# Altium schematic sheet JTAG_to_HDMI.SchDoc(JTAG_to_HDMI)
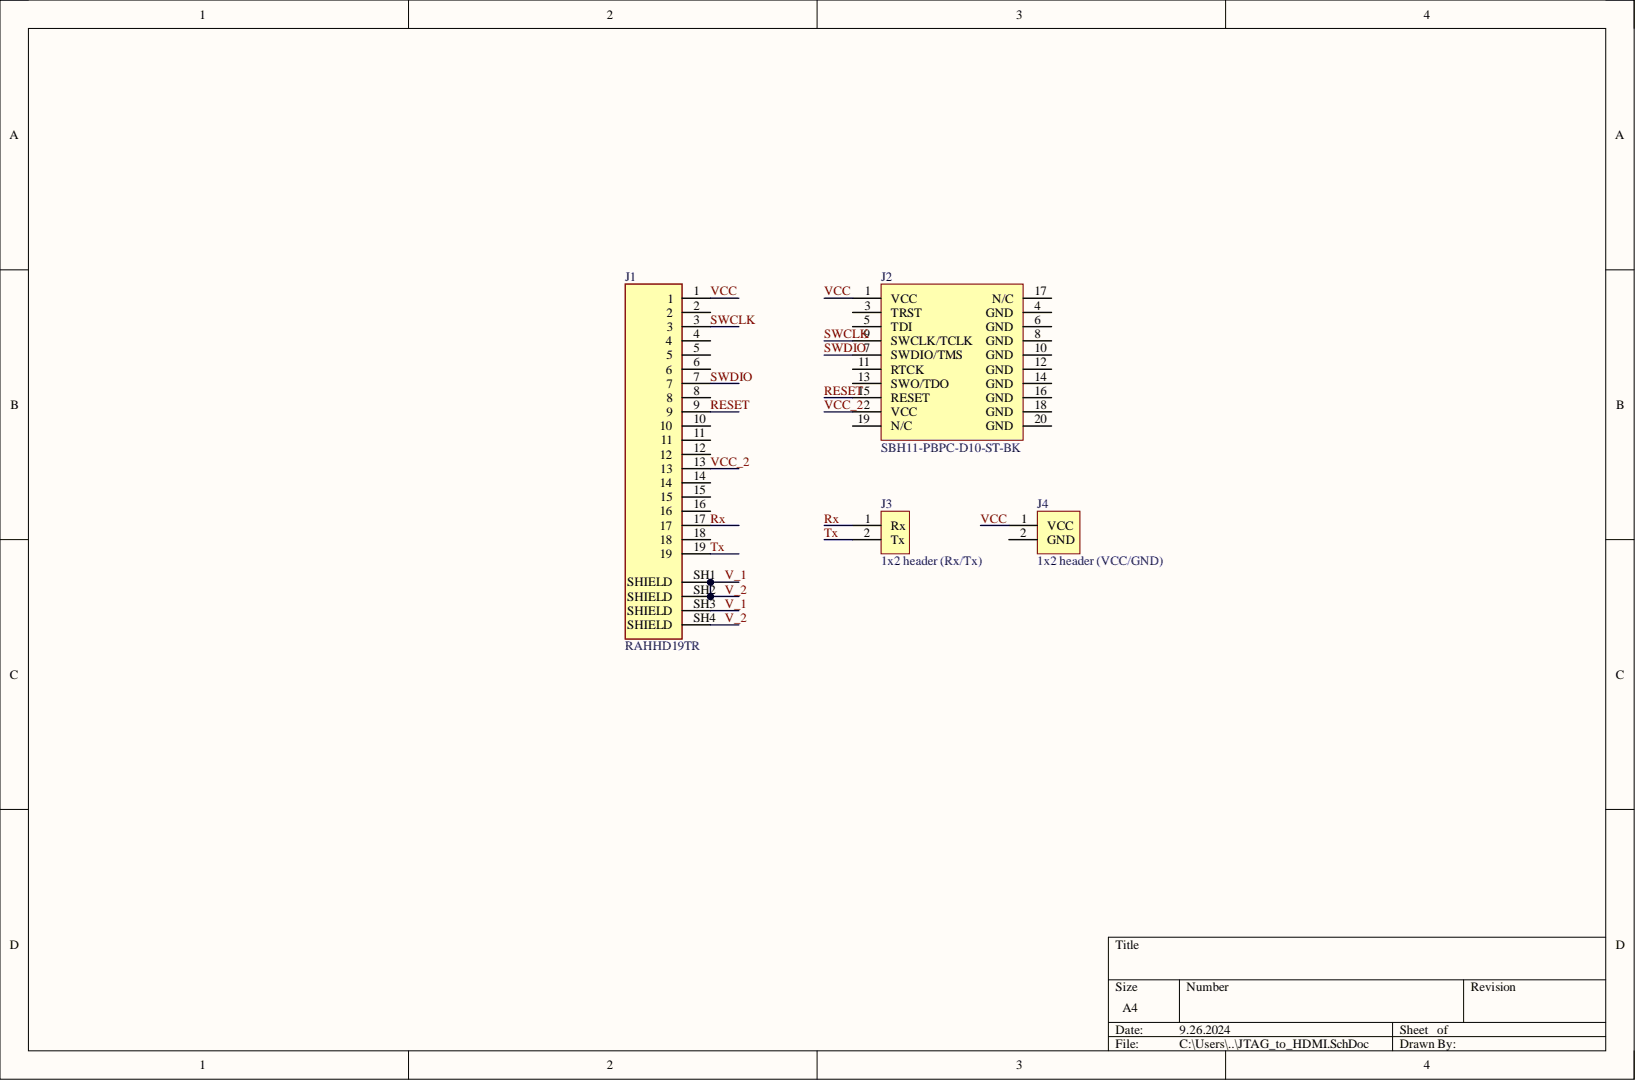
[diagram p0: JTAG_to_HDMI.SchDoc]

## Parts
J1  RAHHD19TR  [SWITCHCRAFT_RAHHD19TR]
J2  SBH11-PBPC-D10-ST-BK  [SBH11-PBPC-D10-ST-BK]
J3  1x2 header (Rx/Tx)  [DS1021-1*2SF1-1]
J4  1x2 header (VCC/GND)  [DS1021-1*2SF1-1]

## Nets
RESET: J1.9 J2.15(RESET)
Rx: J1.17 J3.1
SWCLK: J1.3 J2.9(SWCLK/TCLK)
SWDIO: J1.7 J2.7(SWDIO/TMS)
Tx: J1.19 J3.2
V_1: J1.SH1(SHIELD) J1.SH2(SHIELD) J1.SH3(SHIELD) J1.SH4(SHIELD)
VCC: J1.1 J2.1(VCC) J4.1
VCC_2: J1.13 J2.2(VCC)

Not connected: J1 2 4 5 6 8 10 11 12 14 15 16 18; J2 3(TRST) 4(GND) 5(TDI) 6(GND) 8(GND) 10(GND) 11(RTCK) 12(GND) 13(SWO/TDO) 14(GND) 16(GND) 17(N/C) 18(GND) 19(N/C) 20(GND); J4 2

## Library data of the parts
J1 = RAHHD19TR: HDMI HDMI 2.0 Receptacle Connector 19 Position Surface Mount, Right Angle; Through Hole; MF: Switchcraft Inc.; Availability: In Stock; Check_prices: https://www.snapeda.com/parts/RAHHD19TR/Switchcraft+%252F+Conxall/view-part/?ref=eda; Component Kind: Standard; ComponentLink1Description: Chipdip link; ComponentLink1URL: https://www.chipdip.ru/product0/8007174530; MP: RAHHD19TR; Package: None; Price: None; Purchase-URL: https://pricing.snapeda.com/search/part/RAHHD19TR/?ref=eda; SnapEDA_Link: https://www.snapeda.com/parts/RAHHD19TR/Switchcraft+%252F+Conxall/view-part/?ref=snap; Supplier 1: https://www.chipdip.ru/product0/8007174530; Library Name: JTAG_to_HDMI.SchLib
J2 = SBH11-PBPC-D10-ST-BK: Conn Shrouded Header (4 Sides) HDR 20 POS 2.54mm Solder ST Top Entry Thru-Hole; Manufacturer: Sullins; Category: Connectors; Component Kind: Standard; ComponentLink1Description: https://datasheet.ciiva.com/730/sbh11-pbpc-dxx-st-xx-730919.pdf?src-supplier=Digikey; ComponentLink1URL: https://datasheet.ciiva.com/730/sbh11-pbpc-dxx-st-xx-730919.pdf?src-supplier=Digikey; ComponentLink2Description: Chipdip link; ComponentLink2URL: https://www.chipdip.ru/product0/8005812384; Manufacturer Part Number: SBH11-PBPC-D10-ST-BK; Pricing: 1=0,65, 10=0,496, 80=0,38963, 440=0,32211, 945=0,29663, 4900=0,25024, 10000=0,2457 (USD); RoHS: Yes; Stock: 20189; Supplier: Digikey; Supplier 1: https://www.chipdip.ru/product0/8005812384; Supplier Part Number: S9172-ND; Library Name: JTAG_to_HDMI.SchLib
J3 = DS1021-1*2SF1-1_1: Manufacturer: Ningbo Connfly Electric; Component Kind: Standard; ComponentLink1Description: Chipdip link; ComponentLink1URL: https://www.chipdip.ru/product0/8009330828; Manufacturer Part Number: DS1021-1*2SF1-1; Pricing: 1=0,11 (EUR); RoHS: No; Supplier: Conrad; Supplier 1: https://www.chipdip.ru/product0/8009330828; Supplier Part Number: 1390105; Library Name: JTAG_to_HDMI.SchLib
J4 = DS1021-1*2SF1-1: Manufacturer: Ningbo Connfly Electric; Component Kind: Standard; ComponentLink1Description: Chipdip link; ComponentLink1URL: https://www.chipdip.ru/product0/8009330828; Manufacturer Part Number: DS1021-1*2SF1-1; Pricing: 1=0,11 (EUR); RoHS: No; Supplier: Conrad; Supplier 1: https://www.chipdip.ru/product0/8009330828; Supplier Part Number: 1390105; Library Name: JTAG_to_HDMI.SchLib
